# Altium schematic sheet alim.SchDoc
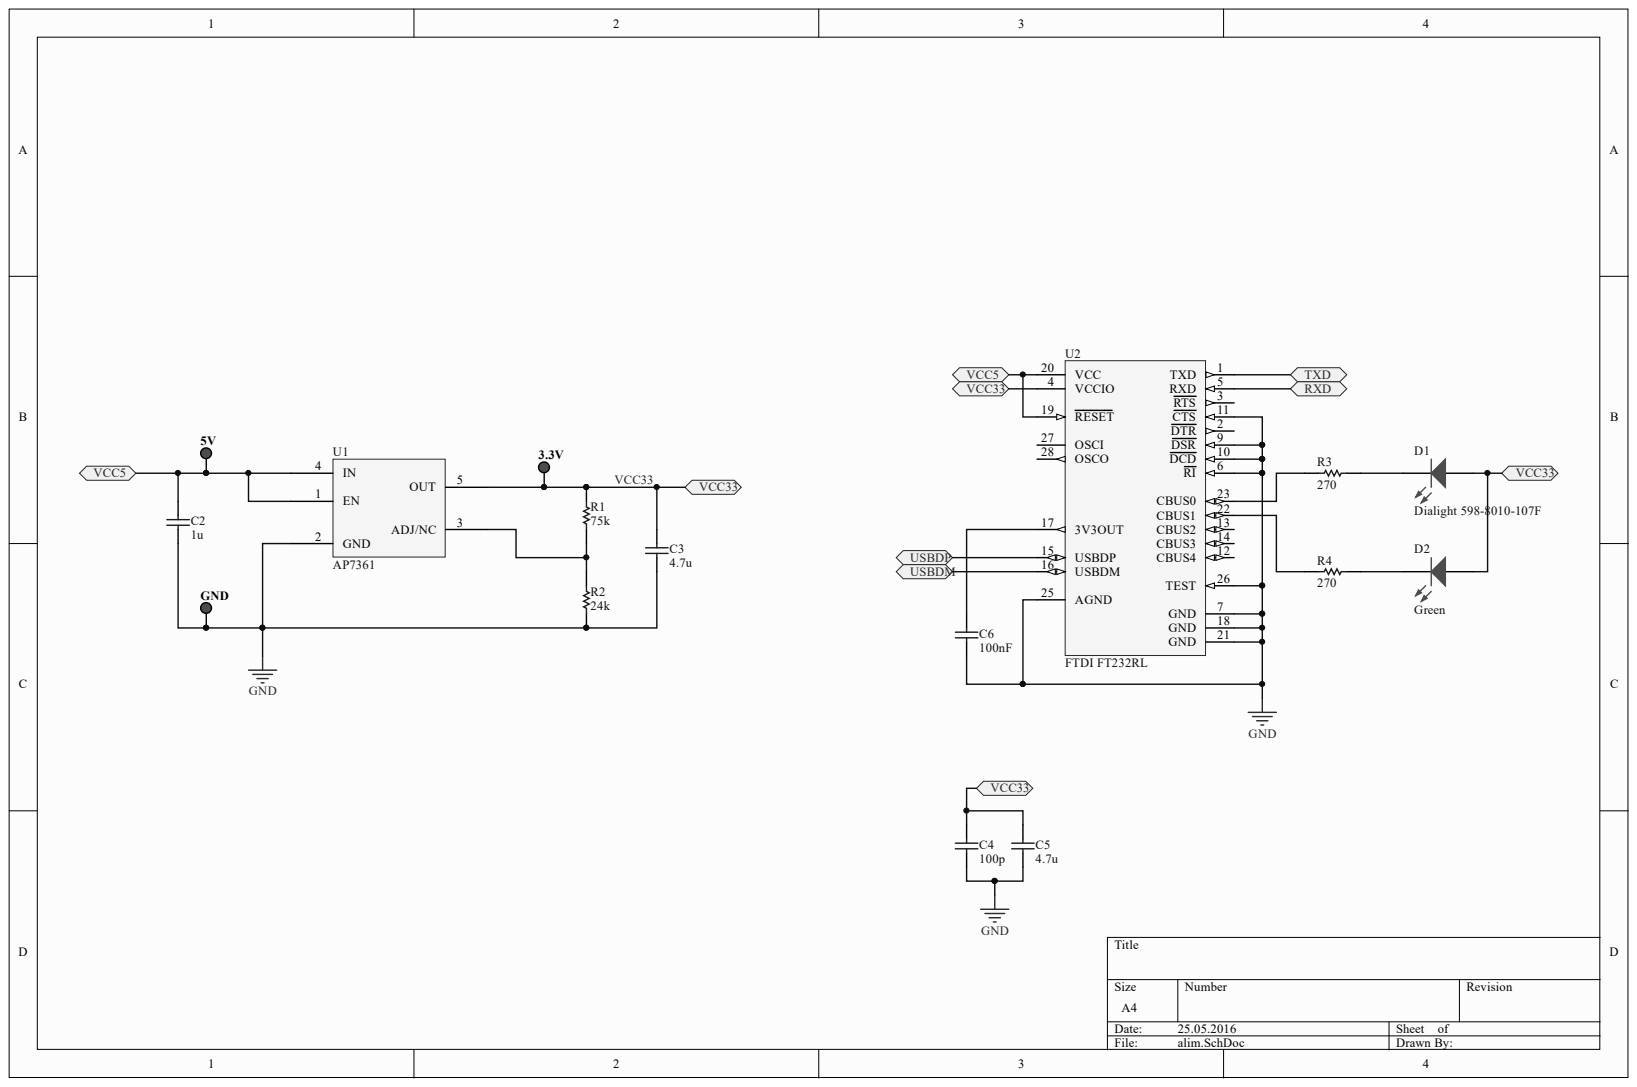
[diagram p0: alim.SchDoc]

## Parts
3.3V  (no value)  [PCB-gm3691gcwmiln8nb0eoq-1]  (no BOM)
5V  (no value)  [PCB-gm3691gcwmiln8nb0eoq-1]  (no BOM)
C2  1u  [CAPC2013X145X50LL20T25]
C3  4.7u  [CAPC2013X145X50LL20T25]
C4  100p  [CAPC1608X87X35LL15T15]
C5  4.7u  [CAPC2013X145X50LL20T25]
C6  100nF  [CAPC1608X87X35LL15T15]
D1  Dialight 598-8010-107F  [LEDC1608X80N]
D2  Green  [LEDC1608X80N]
GND  (no value)  [PCB-gm3691gcwmiln8nb0eoq-1]  (no BOM)
R1  75k  [PCB-4097432-1]
R2  24k  [PCB-4097432-1]
R3  270  [PCB-4097432-1]
R4  270  [PCB-4097432-1]
U1  AP7361  [PCB-30q2bpjxesxttrhziu65-1]
U2  FTDI FT232RL  Manufacturer Part Number: FT232RL  [SSOP28-0.65-10.2X5.2MM]

## Ports
RXD, TXD, USBDM, USBDP, VCC5, VCC33

## Nets
GND: C2.2 C3.1 C4.1 C5.1 C6.1 GND.TP R2.1 U1.2(GND) U2.6(RI) U2.7(GND) U2.9(DSR) U2.10(DCD) U2.11(CTS) U2.18(GND) U2.21(GND) U2.25(AGND) U2.26(TEST)
RXD: U2.5(RXD) ; port RXD
TXD: U2.1(TXD) ; port TXD
USBDM: U2.16(USBDM) ; port USBDM
USBDP: U2.15(USBDP) ; port USBDP
VCC5: 5V.TP C2.1 U1.1(EN) U1.4(IN) U2.19(RESET) U2.20(VCC) ; port VCC5
VCC33: 3.3V.TP C3.2 C4.2 C5.2 D1.1 D2.1 R1.2 U1.5(OUT) U2.4(VCCIO) ; port VCC33
NetC6_2: C6.2 U2.17(3V3OUT)
NetD1_2: D1.2 R3.2
NetD2_2: D2.2 R4.2
NetR1_1: R1.1 R2.2 U1.3(ADJ/NC)
NetR3_1: R3.1 U2.23(CBUS0)
NetR4_1: R4.1 U2.22(CBUS1)

Not connected: U2 2(DTR) 3(RTS) 12(CBUS4) 13(CBUS2) 14(CBUS3) 27(OSCI) 28(OSCO)

## Library data of the parts
3.3V 5V GND = Keystone Electronics 5000-14: KEYSTONE   5000   TEST POINT, PCB, THROUGH HOLE; Manufacturer Part Number: 5000; Lead-Free Status: Lead Free; Color: Red; Contact Material: Phosphor Bronze; Library Name: FavoritesLibrary; Manufacturer: Keystone; OctopartID: c16f0865c3341ea8; Octopart Url: https://octopart.com/5000-keystone-10045; Packaging: Bag; REACH SVHC Compliance: No SVHC; RoHS: Compliant; Size-Diameter: 2.54 mm; Size-Height: 7.62 mm; Size-Inner Diameter: 1.27 mm; Size-Length: 7.62 mm
C2 C3 C5 = Kemet C0805C104J5RAC7800-2: 0805 X7R ceramic capacitor, 50V 0.1uF; Capacitance: 1e-07 F; Capacitance Tolerance: ±5%; Lead-Free Status: R; Voltage Rating (DC): 50.0 V; Case/Package: 0805; Component Kind: Standard; Dielectric Characteristic: X7R; Dielectric Material: Ceramic; Library Name: FavoritesLibrary; Mounting Style: Surface Mount; OctopartID: 9cb2558377904375; Octopart Url: https://octopart.com/c0805c104j5rac7800-kemet-3919428; RoHS: Compliant
C4 = Kemet C0603C104K5RAC7867-2: Multilayer Ceramic Capacitors MLCC - SMD/SMT 50volts 0.1uF X7R 10%; Capacitance: 1e-07 F; Lead-Free Status: R; Voltage Rating (DC): 50.0 V; Capacitance Tolerance: ±10%; Case/Package: 0603; Component Kind: Standard; Dielectric Characteristic: X7R; Dielectric Material: Ceramic; Library Name: FavoritesLibrary; Mounting Style: Surface Mount; OctopartID: 99d350e26f664614; Octopart Url: https://octopart.com/c0603c104k5rac7867-kemet-3920851; RoHS: Compliant
C6 = CMP-8264263-2: Multilayer Ceramic Capacitors MLCC - SMD/SMT 50volts 0.1uF X7R 10%; Capacitance: 1e-07 F; Lead-Free Status: R; Voltage Rating (DC): 50.0 V; Capacitance Tolerance: ±10%; Case/Package: 0603; Component Kind: Standard; Dielectric Characteristic: X7R; Dielectric Material: Ceramic; Library Name: CommunityVault; Mounting Style: Surface Mount; OctopartID: 99d350e26f664614; Octopart Url: https://octopart.com/c0603c104k5rac7867-kemet-3920851; RoHS: Compliant
D1 = CMP-1544913-2: DIALIGHT   5988010107F   LED, 0603, RED, 40MCD, 635NM; Forward Voltage: 2.2 V; Lead-Free Status: Lead Free; Lens Type: Non-Diffused; Luminous Intensity: 0.04 cd; Viewing Angle: 140 °; Wavelength: 6.35e-07 m; Case/Package: 0603; Color: Red; Component Kind: Standard; Library Name: CommunityVault; OctopartID: 4c1d851a285693da; Octopart Url: https://octopart.com/598-8010-107f-dialight-868653; Packaging: Reel; RoHS: Compliant; Size-Height: 0.0007 m; Size-Length: 0.0016 m; Size-Width: 0.0008 m
D2 = Dialight 598-8010-107F-2: DIALIGHT   5988010107F   LED, 0603, RED, 40MCD, 635NM; Forward Voltage: 2.2 V; Lead-Free Status: Lead Free; Lens Type: Non-Diffused; Luminous Intensity: 0.04 cd; Viewing Angle: 140 °; Wavelength: 6.35e-07 m; Case/Package: 0603; Color: Red; Component Kind: Standard; Library Name: FavoritesLibrary; OctopartID: 4c1d851a285693da; Octopart Url: https://octopart.com/598-8010-107f-dialight-868653; Packaging: Reel; RoHS: Compliant; Size-Height: 0.0007 m; Size-Length: 0.0016 m; Size-Width: 0.0008 m
R1-R4 = Bourns CR0805-FX-4701GLF-1: Res Thick Film 0805 4.7K Ohm 1% 1/8W ±100ppm/°C Molded SMD SMD Paper Tape on Plastic Reel; Power Rating: 125 mW; Resistance: 4.70 k?; Voltage Rating (DC): 150 V; Case/Package: 0805; Component Kind: Standard; Library Name: FavoritesLibrary; Manufacturer Part Number: CR0805-FX-4701GLF; Mounting Style: Surface Mount; Number of Pins: 2; OctopartID: 97505e06006c4897; Octopart URL: http://octopart.com/cr0805-fx-4701glf-bourns-6074970; Packaging: Tape & Reel (TR), Cut Tape (CT); REACH SVHC Compliance: No SVHC; Resistance Tolerance: ±1%; RoHS: Compliant; Size-Length: 2.01 mm; Size-Thickness: 508 µm; Size-Width: 1.24 mm
U1 = CMP-liz7mhzrahp5mpd0csjo-2: DCDC converter; Component Kind: Standard; CustomComponentID: liz7mhzrahp5mpd0csjo; Library Name: CommunityVault
U2 = CMP-1159378-7: Interface; USB-UART; Full Speed; SSOP28; Bandwidth: 48000000.0 Hz; Data Rate: 3000000.0 bps; Lead-Free Status: Lead Free; Supply Current: 0.015 A; Component Kind: Standard; Library Name: CommunityVault; Mounting Style: Surface Mount; Number of Pins: 28; OctopartID: bcc675ec4d616301; Octopart Url: http://octopart.com/ft232rl-ftdi-2151617; Packaging: Tube; REACH SVHC Compliance: No SVHC; RoHS: Compliant; Seller Landing  Url: https://octopart-clicks.com/click/track?country=US&ak=8157f166&sig=094a7e7&sid=10022&ppid=2151617&vpid=89381441&ct=offers
